# Altium schematic sheet Engine Sensors Breakaway.SchDoc
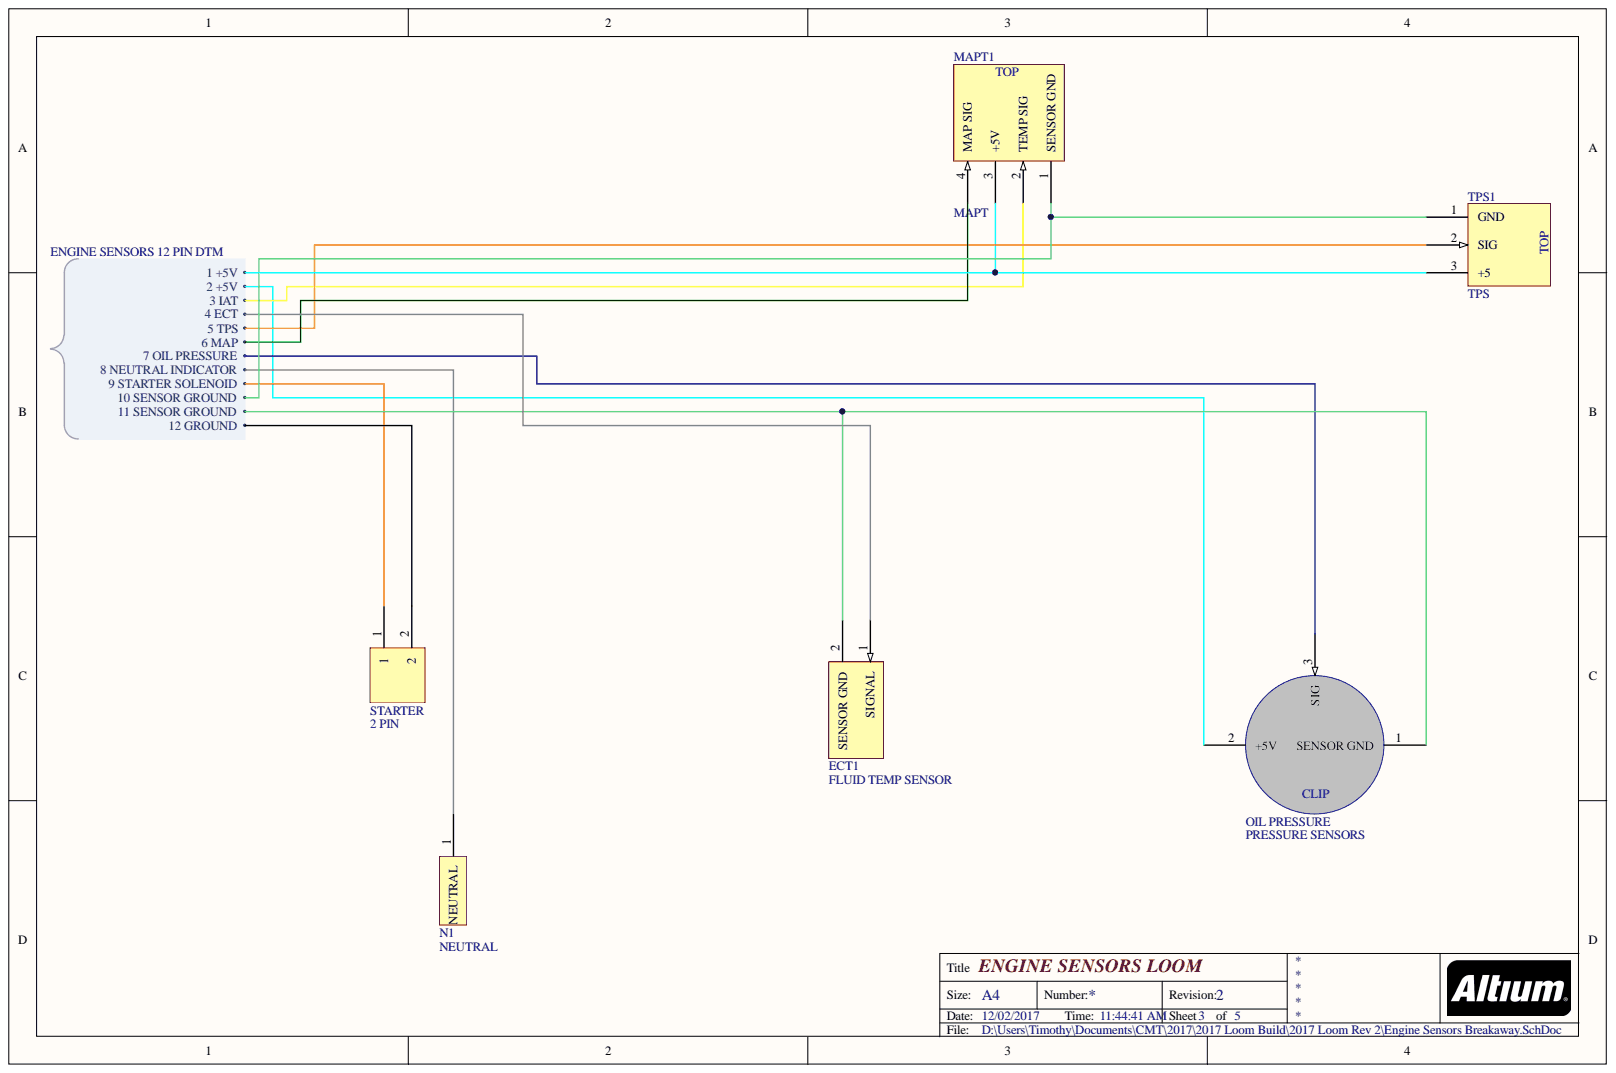
[diagram p0: Engine Sensors Breakaway.SchDoc]

## Parts
ECT1  FLUID TEMP SENSOR
MAPT1  MAPT
N1  NEUTRAL
OIL_PRESSURE  PRESSURE SENSORS
STARTER  2 PIN  (value not drawn)
TPS1  TPS

## Nets
NetECT1_2: ECT1.2 OIL_PRESSURE.1
NetMAPT1_1: MAPT1.1(SENSOR_GND) TPS1.1
NetMAPT1_3: MAPT1.3(+5V) TPS1.3

Not connected: ECT1 1; MAPT1 2(TEMP_SIG) 4(MAP_SIG); N1 1; OIL_PRESSURE 2 3; STARTER 1 2; TPS1 2

## Library data of the parts
ECT1 = FLUID TEMP SENSOR: FOR OIL, FUEL, WATER; Component Kind: Standard; Library Name: Loom Components.SchLib
MAPT1 = MAPT: BOSCH 4 BAR MAP AND TEMP; Component Kind: Standard; Library Name: Loom Components.SchLib
OIL_PRESSURE = PRESSURE SENSORS: TEXAS INSTRUMENTS 150 PSI; Component Kind: Standard; Library Name: Loom Components.SchLib
TPS1 = TPS: ACUITY HALL EFFECT TPS; Component Kind: Standard; Library Name: Loom Components.SchLib
N1 = NEUTRAL: Component Kind: Standard; Library Name: Loom Components.SchLib
STARTER = 2 PIN: Component Kind: Standard; Library Name: Loom Connectors.SchLib
